# Altium schematic sheet motordriver_hgh voltage.SchDoc("motordriver_hgh voltage")
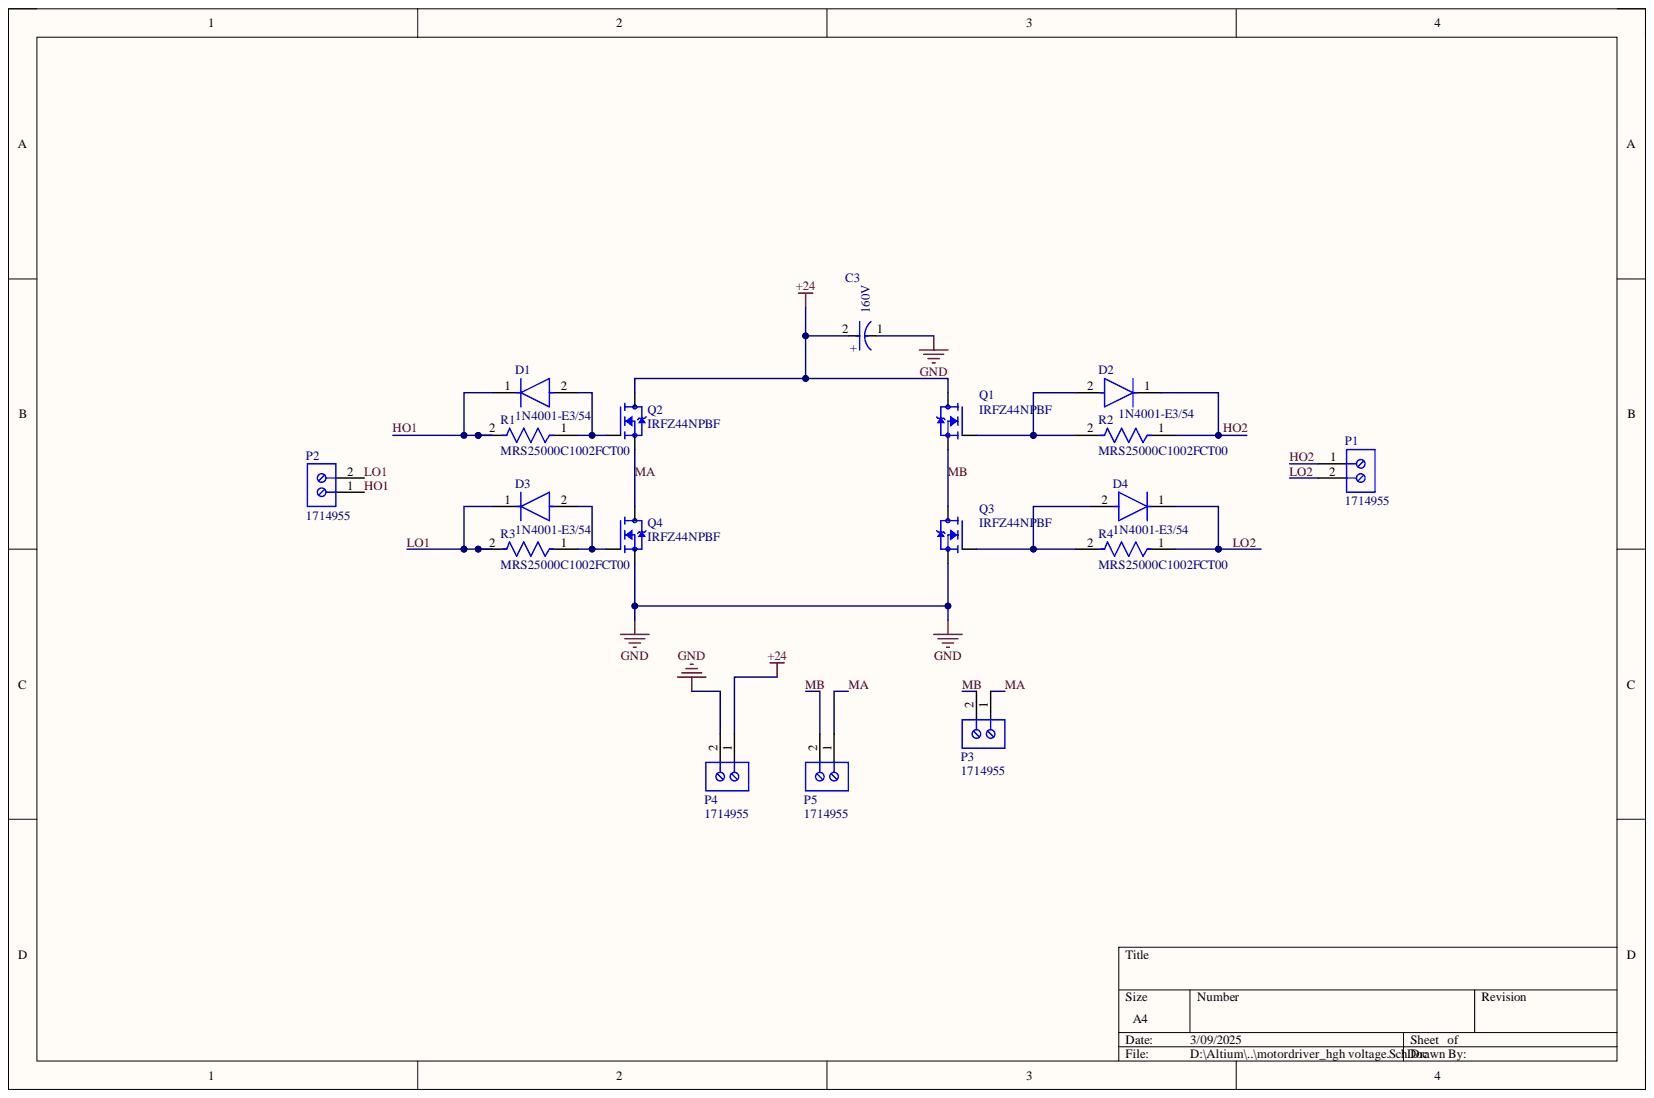
[diagram p0: motordriver_hgh voltage.SchDoc]

## Parts
C3  EEUED2V101  [FP-RAD-TH-D_18_0_5-L_31_5-MFG]  (value not drawn)
D1  1N4001-E3/54  [FP-1N4001-E3-54-MFG]
D2  1N4001-E3/54  [FP-1N4001-E3-54-MFG]
D3  1N4001-E3/54  [FP-1N4001-E3-54-MFG]
D4  1N4001-E3/54  [FP-1N4001-E3-54-MFG]
P1  1714955  [PHOE-1714955_V]
P2  1714955  [PHOE-1714955_V]
P3  1714955  [PHOE-1714955_V]
P4  1714955  [PHOE-1714955_V]
P5  1714955  [PHOE-1714955_V]
Q1  IRFZ44NPBF  [TO254P1016X419X2286-3]
Q2  IRFZ44NPBF  [TO254P1016X419X2286-3]
Q3  IRFZ44NPBF  [TO254P1016X419X2286-3]
Q4  IRFZ44NPBF  [TO254P1016X419X2286-3]
R1  MRS25000C1002FCT00  [FP-MRS25-MFG]
R2  MRS25000C1002FCT00  [FP-MRS25-MFG]
R3  MRS25000C1002FCT00  [FP-MRS25-MFG]
R4  MRS25000C1002FCT00  [FP-MRS25-MFG]

## Nets
+24: C3.2 P4.1 Q1.2 Q2.2
GND: C3.1 P4.2 Q3.3 Q4.3
HO1: D1.1 P2.1 R1.2
HO2: D2.1 P1.1 R2.1
LO1: D3.1 P2.2 R3.2
LO2: D4.1 P1.2 R4.1
MA: P3.1 P5.1 Q2.3 Q4.2
MB: P3.2 P5.2 Q1.3 Q3.2
NetD1_2: D1.2 Q2.1 R1.1
NetD2_2: D2.2 Q1.1 R2.2
NetD3_2: D3.2 Q4.1 R3.1
NetD4_2: D4.2 Q3.1 R4.2

## Library data of the parts
C3 = CMP-05427-001282-1: Aluminum Electrolytic Capacitors (Radial Lead Type) 100uF ±20% 350V; Dielectric Material: Aluminium; Dissipation Factor: 0.2%; Lead Diameter: 0.8mm; Life (Hours): 10000Hour; Ripple Current: 1.2A; Capacitance: 100uF; Case/Package: Radial; Component Kind: Standard; Construction: RADIAL; Diameter: 18mm; Height: 31.5mm; Height - Seated (Max): 1.24inch; Lead Free: Lead Free; Lead Pitch: 7.5mm; Length: 31.5mm; Library Name: Altium Content Vault; Max Operating Temperature: 105°C; Min Operating Temperature: -25°C; Mount: Through Hole; Number of Pins: 2; Package Quantity: 50; Packaging: Bulk; Radiation Hardening: No; REACH SVHC: No SVHC; RoHS Compliant: Yes; Series: ED; Termination: Radial; Tolerance: 20%; Voltage: 350V; Voltage Rating: 350V; Voltage Rating (DC): 350V
D1-D4 = CMP-02540-000077-1: DIODE GEN PURP 50V 1A DO204AL; Capacitance: 15pF; Element Configuration: Single; Forward Voltage: 1.1V; Max Forward Surge Current (Ifsm): 30A; Max Reverse Current: 5uA; Peak Non-Repetitive Surge Current: 45A; Polarity: Standard; Average Rectified Current: 1A; Case/Package: DO-204AL; Component Kind: Standard; Current: 1A; Current Rating: 1A; Diameter: 2.7mm; Forward Current: 1A; Height: 2.7mm; Lead Free: Lead Free; Length: 0.204inch; Library Name: Altium Content Vault; Max Operating Temperature: 150°C; Max Repetitive Reverse Voltage (Vrrm): 50V; Max Reverse Voltage (DC): 50V; Max Surge Current: 30A; Min Operating Temperature: -50°C; Mount: Through Hole; Number of Pins: 2; Output Current: 1A; Package Quantity: 5500; Packaging: Tape and Reel; Radiation Hardening: No; Reverse Voltage: 50V; RoHS Compliant: Yes; Series: -; Voltage: 50V; Voltage Rating (DC): 50V; Width: 2.7mm
P1-P5 = CMP-2000-05797-1: PC Terminal Block, Pitch 6.35 mm, 1 x 2 Position, Height 21.5 mm, Tail Lenght 5.1 mm, RoHS, Bulk; Manufacturer: Phoenix Contact; Component Kind: Standard; Datasheet URL: http://media.digikey.com/pdf/Data%20Sheets/Phoenix%20Contact%20PDFs/1714955.pdf; Datasheet Version: 02/2010; Library Name: Altium Content Vault; Manufacturer URL: http://www.phoenixcontact.com/; Mounting Technology: ThroughHole; Package Description: PC Terminal Block, Pitch 6.35 mm, 1 x 2 Position, Height 21.5 mm, Tail Lenght 5.1 mm; Package Reference: 1714955
Q1-Q4 = IRFZ44NPBF: N-Channel 55 V 49A (Tc) 94W (Tc) Through Hole TO-220AB; MF: Infineon; Check_prices: https://www.snapeda.com/parts/IRFZ44NPBF/Infineon+Technologies/view-part/?ref=eda; Component Kind: Standard; Digi-Key_Part_Number: IRFZ44NPBF-ND; Library Name: IRFZ44NPBF.IntLib; MP: IRFZ44NPBF; Package: TO-220-3 Infineon; Purchase-URL: https://pricing.snapeda.com/search/part/IRFZ44NPBF/?ref=eda; SnapEDA_Link: https://www.snapeda.com/parts/IRFZ44NPBF/Infineon+Technologies/view-part/?ref=snap
R1-R4 = CMP-00030-42632392-1: MRS25000C1002FCT00 Resistor, 10 kOhm, ± 1%, 600 mW, Axial Leaded, Metal Film (Thin Film), Professional; Lead Length: 26mm; Max Power Dissipation: 600mW; Resistance: 10kΩ; Temperature Coefficient: 50ppm/°C; Voltage Rating: 350V; Case/Package: Conformal; Component Kind: Standard; Composition: Metal Film, Thin Film; Contact Plating: Tin; Diameter: 2.5mm; Lead Free: Lead Free; Length: 6.5mm; Library Name: Altium Content Vault; Max Operating Temperature: 155°C; Military Standard: Not; Min Operating Temperature: -55°C; Mount: Through Hole; NexarPartId: 42632392; Number of Pins: 2; Number of Terminations: 2; Power Rating: 600mW; Radiation Hardening: No; REACH SVHC: Unknown; RoHS: Compliant; Schedule B: 8533210090, 8533210090; Termination: Axial; Tolerance: 1%; Voltage Rating (DC): 350V; Width: 2.5mm
